# Altium schematic sheet CW308T_EFR32MG21.SchDoc
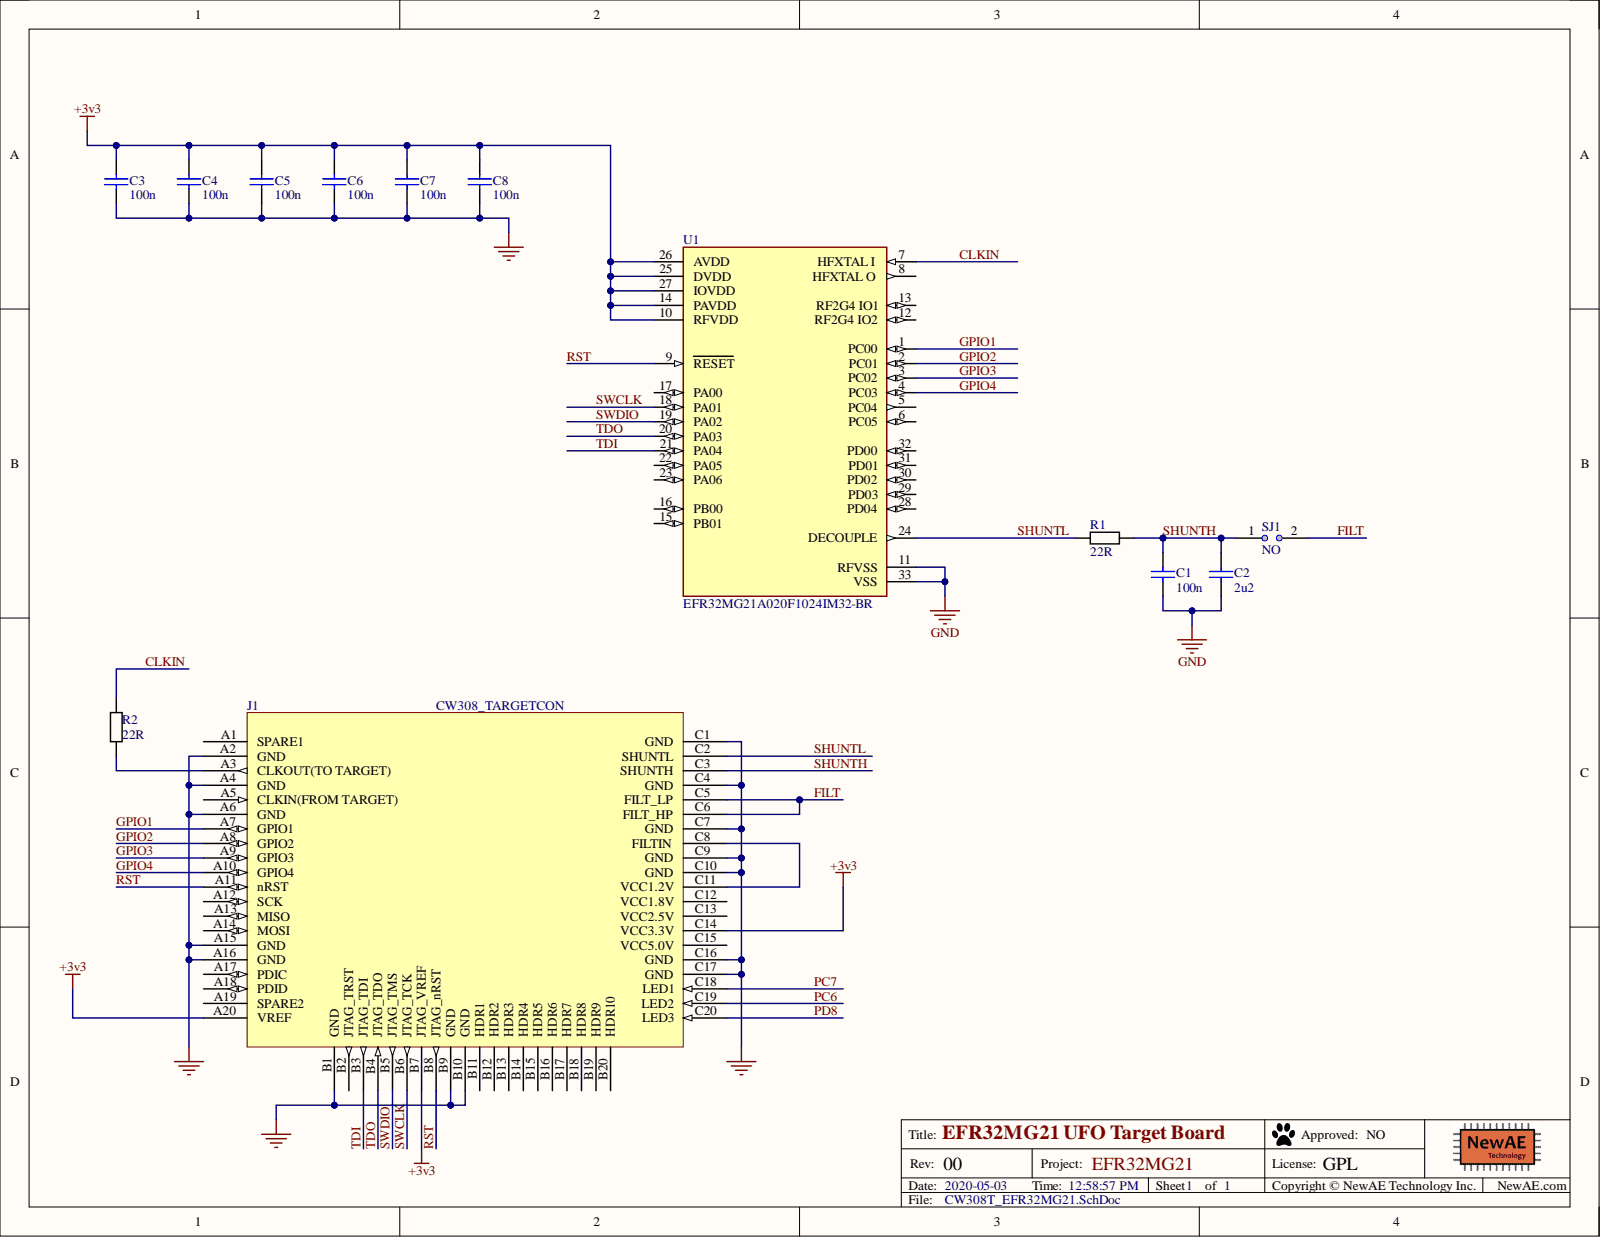
[diagram p0: CW308T_EFR32MG21.SchDoc]

## Parts
C1  100n  [0603]
C2  2u2  [0603]
C3  100n  [0603]
C4  100n  [0603]
C5  100n  [0603]
C6  100n  [0603]
C7  100n  [0603]
C8  100n  [0603]
J1  CW308_TARGETCON  [CW308_TBOARD_CONN]
R1  22R  [0603]
R2  22R  [0603]
SJ1  NO  [SJ_2S_NO]  (no BOM)
U1  EFR32MG21A020F1024IM32-BR  [FP-EFR32MG21A020F1024IM32-MFG]

## Nets
+3v3: C3.1 C4.1 C5.1 C6.1 C7.1 C8.1 J1.A20(VREF) J1.B7(JTAG_VREF) J1.C14(VCC3.3V) U1.10(RFVDD) U1.14(PAVDD) U1.25(DVDD) U1.26(AVDD) U1.27(IOVDD)
GND: C1.2 C2.2 C3.2 C4.2 C5.2 C6.2 C7.2 C8.2 J1.A2(GND) J1.A4(GND) J1.A6(GND) J1.A15(GND) J1.A16(GND) J1.B1(GND) J1.B9(GND) J1.B10(GND) J1.C1(GND) J1.C4(GND) J1.C7(GND) J1.C9(GND) J1.C10(GND) J1.C16(GND) J1.C17(GND) U1.11(RFVSS) U1.33(VSS)
CLKIN: R2.1 U1.7(HFXTAL_I)
FILT: J1.C5(FILT_LP) J1.C6(FILT_HP) SJ1.2
GPIO1: J1.A7(GPIO1) U1.1(PC00)
GPIO2: J1.A8(GPIO2) U1.2(PC01)
GPIO3: J1.A9(GPIO3) U1.3(PC02)
GPIO4: J1.A10(GPIO4) U1.4(PC03)
PC6: J1.C19(LED2)
PC7: J1.C18(LED1)
PD8: J1.C20(LED3)
RST: J1.A11(nRST) J1.B8(JTAG_nRST) U1.9(RESET)
SHUNTH: C1.1 C2.1 J1.C3(SHUNTH) R1.2 SJ1.1
SHUNTL: J1.C2(SHUNTL) R1.1 U1.24(DECOUPLE)
SWCLK: J1.B6(JTAG_TCK) U1.18(PA01)
SWDIO: J1.B5(JTAG_TMS) U1.19(PA02)
TDI: J1.B3(JTAG_TDI) U1.21(PA04)
TDO: J1.B4(JTAG_TDO) U1.20(PA03)
NetJ1_A3: J1.A3(CLKOUT(TO_TARGET)) R2.2
NetJ1_C8: J1.C8(FILTIN) J1.C11(VCC1.2V)

Not connected: J1 A1(SPARE1) A5(CLKIN(FROM_TARGET)) A12(SCK) A13(MISO) A14(MOSI) A17(PDIC) A18(PDID) A19(SPARE2) B2(JTAG_TRST) B11(HDR1) B12(HDR2) B13(HDR3) B14(HDR4) B15(HDR5) B16(HDR6) B17(HDR7) B18(HDR8) B19(HDR9) B20(HDR10) C12(VCC1.8V) C13(VCC2.5V) C15(VCC5.0V); U1 5(PC04) 6(PC05) 8(HFXTAL_O) 12(RF2G4_IO2) 13(RF2G4_IO1) 15(PB01) 16(PB00) 17(PA00) 22(PA05) 23(PA06) 28(PD04) 29(PD03) 30(PD02) 31(PD01) 32(PD00)

## Library data of the parts
C1 C3-C8 = CCHIP-100n-0603: 100n, 0603; Manufacture 1: Kemet; Manufacture Part Number 1: C0603C104K5RACTU; Case-Metric: 1608; Component Group: Capacitor; Database Table Name: main; Rated Voltage: 50VDC; Case-EIA: 0603; Component Kind: Standard; Component Type: Standard; Library Name: NewAE_AltiumLib.DBLib; Mounting Technology: SMT; Part Number: CCHIP-100n-0603; Pin Count: 2; Supplier 1: Digi-Key; Supplier Part Number 1: 399-5089-2-ND; Value: 100n
C2 = CCHIP-2u2-0603: 2u2, 0603; Manufacture 1: Samsung; Manufacture Part Number 1: CL10B225KP8NNNC; Case-Metric: 1608; Component Group: Capacitor; Database Table Name: main; Rated Voltage: 10VDC; Case-EIA: 0603; Component Kind: Standard; Component Type: Standard; Library Name: NewAE_AltiumLib.DBLib; Mounting Technology: SMT; Part Number: CCHIP-2u2-0603; Pin Count: 2; Supplier 1: Digi-Key; Supplier Part Number 1: 1276-1134-2-ND; Value: 2u2
J1 = CW308_TBOARD: CW308 "Standard" Target Board Connector; Component Group: Mechanical; Database Table Name: main; Pitch: 2.54mm; Component Kind: Standard; Component Type: Standard; Library Name: NewAE_AltiumLib.DBLib; Mounting Technology: Through-hole; Part Number: CW308_TBOARD; Pin Count: 60
R1 R2 = RCHIP-22R-0603: 22R res, 0603, 1%, 1/10W; Manufacture 1: Yageo; Manufacture Part Number 1: RC0603FR-0722RL; Case-Metric: 1608; Component Group: Resistor; Database Table Name: main; Rated Power: 0.1W; Rated Voltage: 75VDC; Case-EIA: 0603; Component Kind: Standard; Component Type: Standard; Library Name: NewAE_AltiumLib.DBLib; Mounting Technology: SMT; Part Number: RCHIP-22R-0603; Pin Count: 2; Supplier 1: Digi-Key; Supplier Part Number 1: 311-22.0HRCT-ND; Temperature Range: -55C to 155C; Tolerance: 1%; Value: 22R
SJ1 = SJ_2S_NO: Solder Jumper, NO; Component Group: PCB Feature; Database Table Name: main; Component Type: Standard (No BOM); Library Name: NewAE_AltiumLib.DBLib; Mounting Technology: COPPER; Part Number: SJ_2S_NO; Pin Count: 2
U1 = CMP-08085-000003-1: IC MCU 2.4G 20DB MULTIPROTOCOL; Manufacturer: Silicon Labs; Core Architecture: ARM Cortex-M33; Data Bus Width: 32bits; Frequency: 80MHz; Interface: I2C,SPI,UART,USART,IrDA,I2S,SMBus,RS-485,Other; Max Junction Temp: +135°C; Max Supply Voltage: 3.8V; Memory Size: 1024KBytes; Memory Type: FLASH; Min Supply Voltage: 1.71V; Number Of A/D Converters: 1; Number Of I2C Channels: 2; Number Of I/Os: 20; Number Of Pwm Channels: 6; Number Of Spi Channels: 3; Number Of Timers/Counters: 5; Ram Size: 96KBytes; Standoff Height: 0mm; Ambient Temperature Range High: +125°C; Ambient Temperature Range Low: -40°C; Automotive: No; Category: IC; Component Kind: Standard; ComponentLink2Description: Datasheet; ComponentLink2URL: https://www.silabs.com/documents/public/data-sheets/efr32mg21-datasheet.pdf; Device Class L1: Integrated Circuits (ICs); Device Class L2: Embedded Processors and Controllers; Device Class L3: Microcontrollers; Digikey Description: IC MCU 2.4G 20DB MULTIPROTOCOL; Height: 0.9mm; Ipc Land Pattern Name: QFN40P400X400X85-32; Lead Free: Yes; Library Name: Altium Content Vault; Manufacturer Part Number: EFR32MG21A020F1024IM32-BR; Number Of Adc Channels: 1; Number Of Cores: 1; Number Of Uart Channels: 3; Octopart: https://octopart.com/efr32mg21a020f1024im32-br-silicon+labs-98975230; Package: 0; RoHS: TRUE; Temperature: to +135°C; Temperature Range High: +135°C
